# Altium schematic sheet RA_64pin_Local_Promotion_Board_5.SchDoc(RA_64pin_Local_Promotion_Board_5)
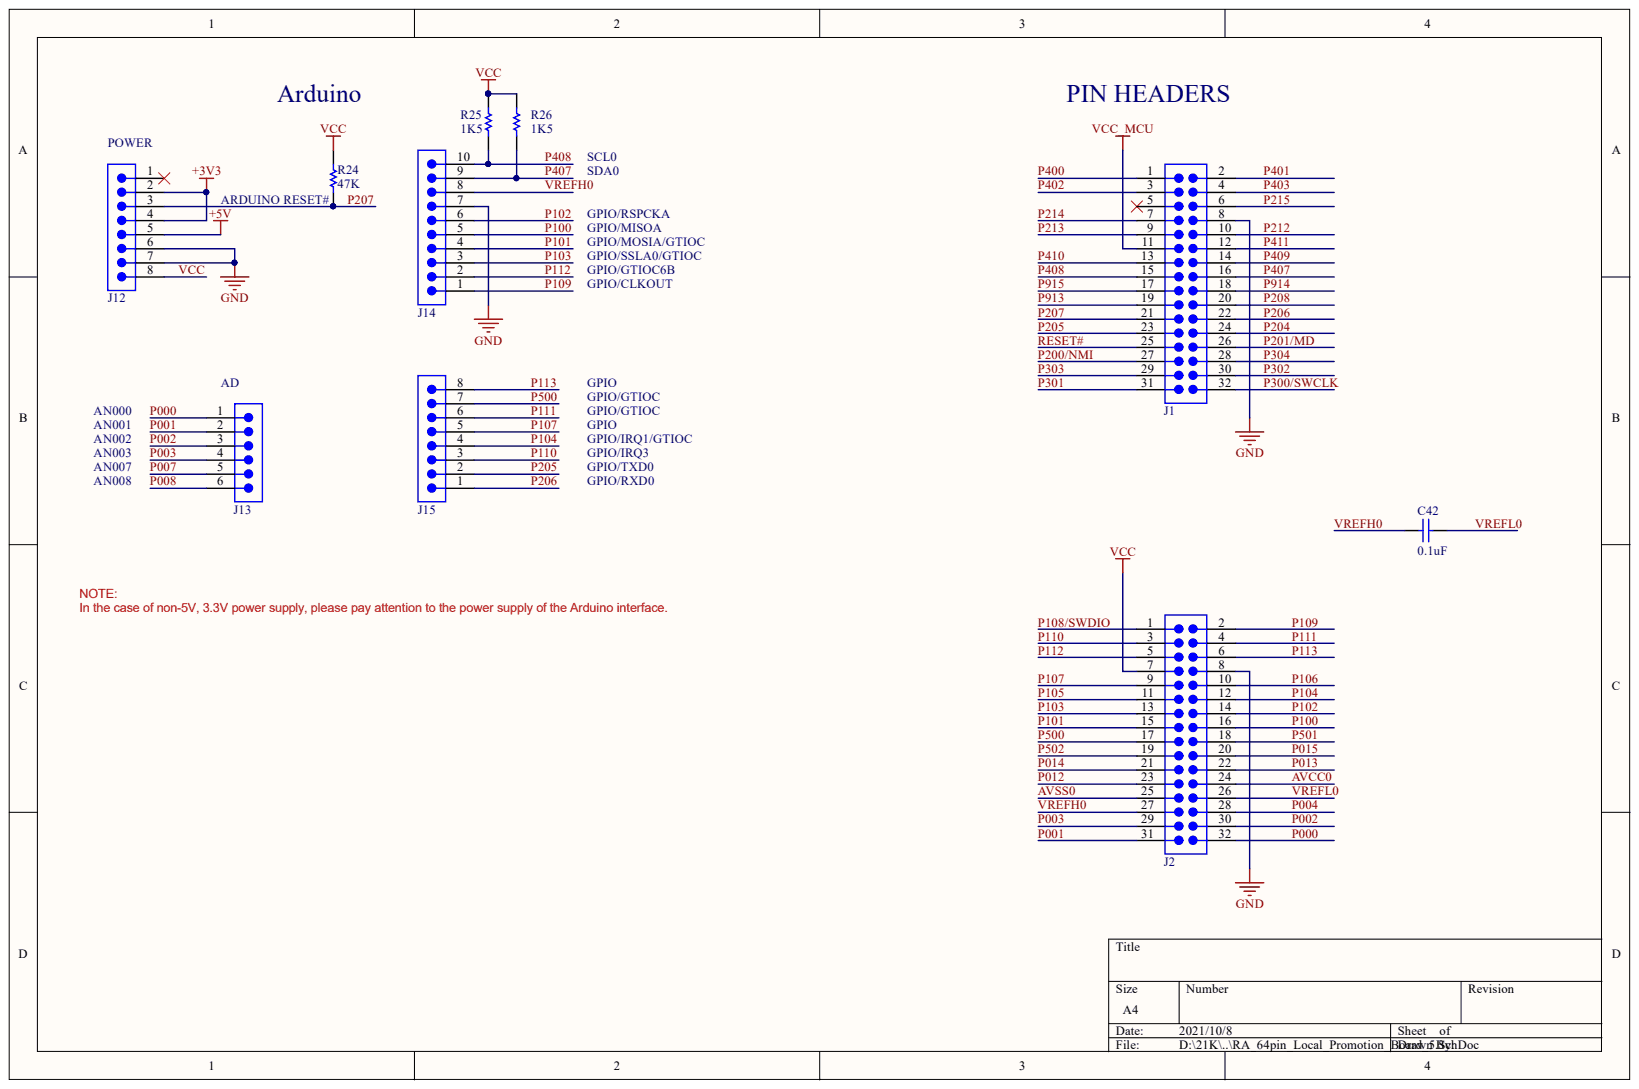
[diagram p0: RA_64pin_Local_Promotion_Board_5.SchDoc]

## Parts
C42  0.1uF  [CAPC0603-01]
J1  2x16  [HDR2X16]  (value not drawn)
J2  2x16  [SULLINS_PPPC202LFBN-RC]  (value not drawn)
J12  2.54mm 1x8  [FCI_77311-818-08LF]  (value not drawn)
J13  2.54mm 1x6  [FCI_77311-818-06LF]  (value not drawn)
J14  2.54mm 1x10  [FCI_77311-818-10LF]  (value not drawn)
J15  2.54mm 1x8  [FCI_77311-818-08LF]  (value not drawn)
R24  Resistance: 47K  [RESC0603-01]  (hidden comment: 4K7)
R25  Resistance: 1K5  [RESC0603-01]  (hidden comment: 4K7)
R26  Resistance: 1K5  [RESC0603-01]  (hidden comment: 4K7)

## Nets
+3V3: J12.2 J12.4
+5V: J12.5
GND: J1.8 J2.8 J12.6 J12.7 J14.7
VCC_MCU: J1.11
AVCC0: J2.24
AVSS0: J2.25
P000: J2.32 J13.1
P001: J2.31 J13.2
P002: J2.30 J13.3
P003: J2.29 J13.4
P004: J2.28
P007: J13.5
P008: J13.6
P012: J2.23
P013: J2.22
P014: J2.21
P015: J2.20
P100: J2.16 J14.5
P101: J2.15 J14.4
P102: J2.14 J14.6
P103: J2.13 J14.3
P104: J2.12 J15.4
P105: J2.11
P106: J2.10
P107: J2.9 J15.5
P108/SWDIO: J2.1
P109: J2.2 J14.1
P110: J2.3 J15.3
P111: J2.4 J15.6
P112: J2.5 J14.2
P113: J2.6 J15.8
P200/NMI: J1.27
P201/MD: J1.26
P204: J1.24
P205: J1.23 J15.2
P206: J1.22 J15.1
P207: J1.21 J12.3 R24.2
P208: J1.20
P212: J1.10
P213: J1.9
P214: J1.7
P215: J1.6
P300/SWCLK: J1.32
P301: J1.31
P302: J1.30
P303: J1.29
P304: J1.28
P400: J1.1
P401: J1.2
P402: J1.3
P403: J1.4
P407: J1.16 J14.9 R26.2
P408: J1.15 J14.10 R25.2
P409: J1.14
P410: J1.13
P411: J1.12
P500: J2.17 J15.7
P501: J2.18
P502: J2.19
P913: J1.19
P914: J1.18
P915: J1.17
RESET#: J1.25
VCC: J2.7 J12.8 R24.1 R25.1 R26.1
VREFH0: C42.1 J2.27 J14.8
VREFL0: C42.2 J2.26

Not connected: J1 5; J12 1

## Library data of the parts
C42 = CAP-0603-0.1uF: Ceramic Chip Capacitor 0603 0.1uF 50V; Manufacturer: MURATA; Mfg Part Number: GCM188L81H104KA57D; Dialectric: X8L; Tolerance: 10%; Capacitance: 0.1uF; Component Kind: Standard; Library Name: New Com.SchLib; Package: 0603; Voltage: 50V
J1 J2 = CON-2.54mm 2x16 Male: Header Male 2.54mm 40pos 2x16; Manufacturer: Sullins Connector Solutions; Mfg Part Number: PRPC016DAAN-RC; Positions: 40; Component Kind: Standard; Library Name: New Com.SchLib; Pitch: 2.54mm
J12 J15 = CON-2.54mm 1x8: FCI Header 0.1" pitch 8pos 1x8; Mfg Part Number: 77311-818-08LF; Positions: 8; Component Kind: Standard; Manufacturer: FCI; Pitch: 2.54mm; Library Name: Synergy.SchLib
J13 = CON-2.54mm 1x6: FCI Header 0.1" pitch 6pos 1x6; Mfg Part Number: 77311-818-06LF; Positions: 6; Component Kind: Standard; Manufacturer: FCI; Pitch: 2.54mm; Library Name: Synergy.SchLib
J14 = CON-2.54mm 1x10: FCI Header 0.1" pitch 10pos 1x10; Mfg Part Number: 77311-818-10LF; Positions: 10; Component Kind: Standard; Manufacturer: FCI; Pitch: 2.54mm; Library Name: Synergy.SchLib
R24-R26 = RES-0603-4K7: Chip Resistor Thick Film 0603 4K7 1% 1/10W; Manufacturer: YAGEO; Mfg Part Number: RC0603FR-074K7L; Component Kind: Standard; Library Name: New Com.SchLib; Package: 0603; Power: 1/10W; Tolerance: 1%
